# Altium schematic sheet Power Selection - AUX.SchDoc
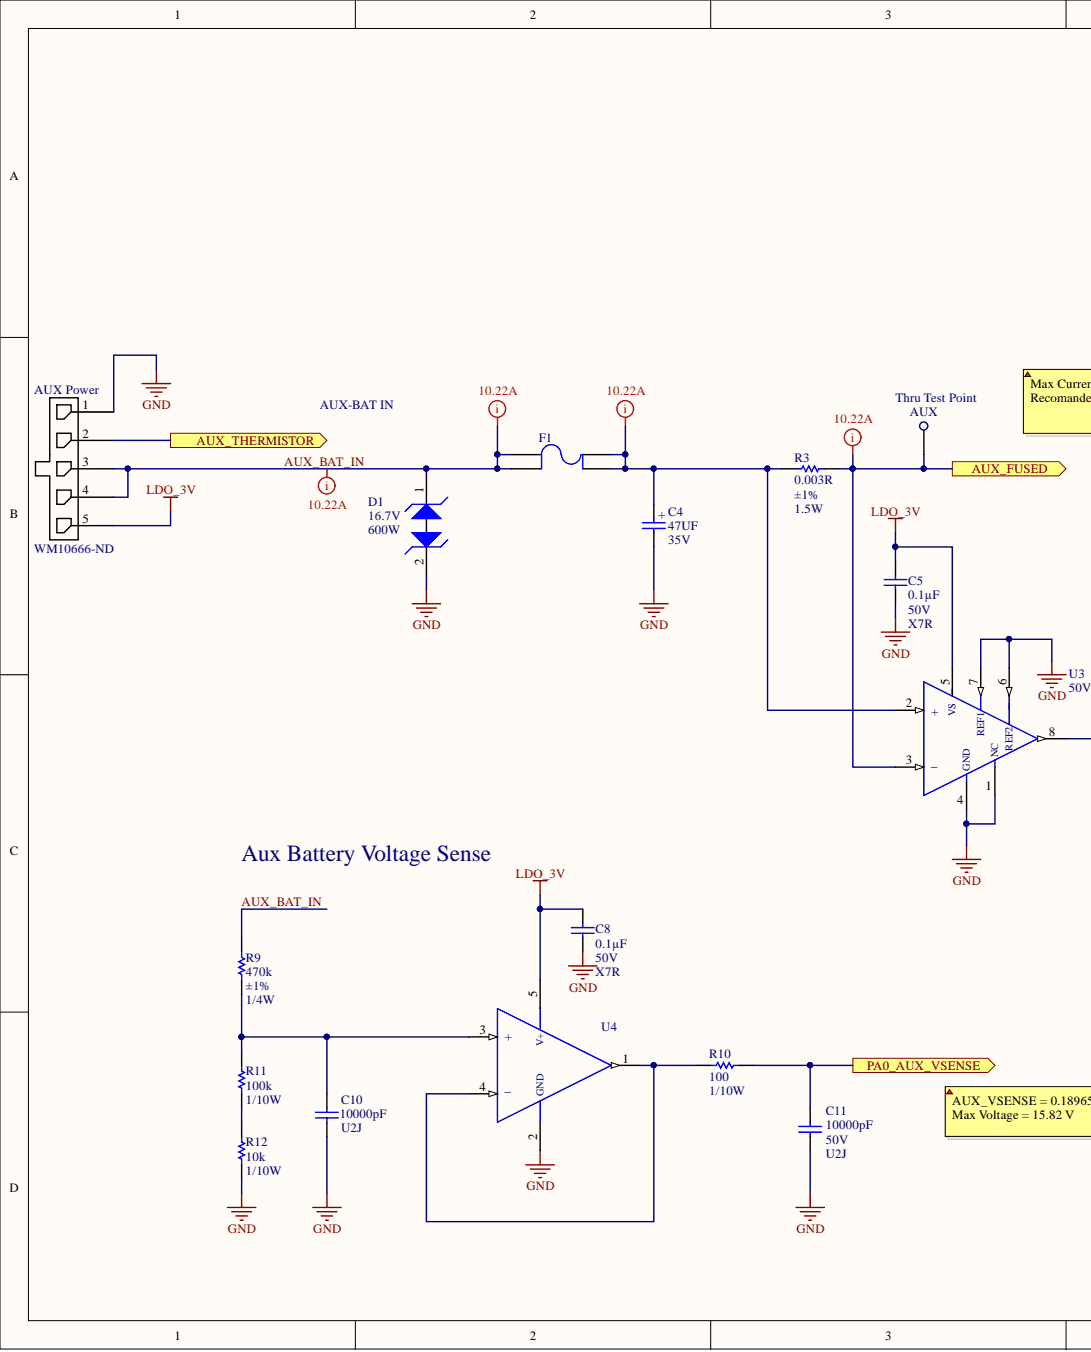
[diagram p0: Power Selection - AUX.SchDoc, left]
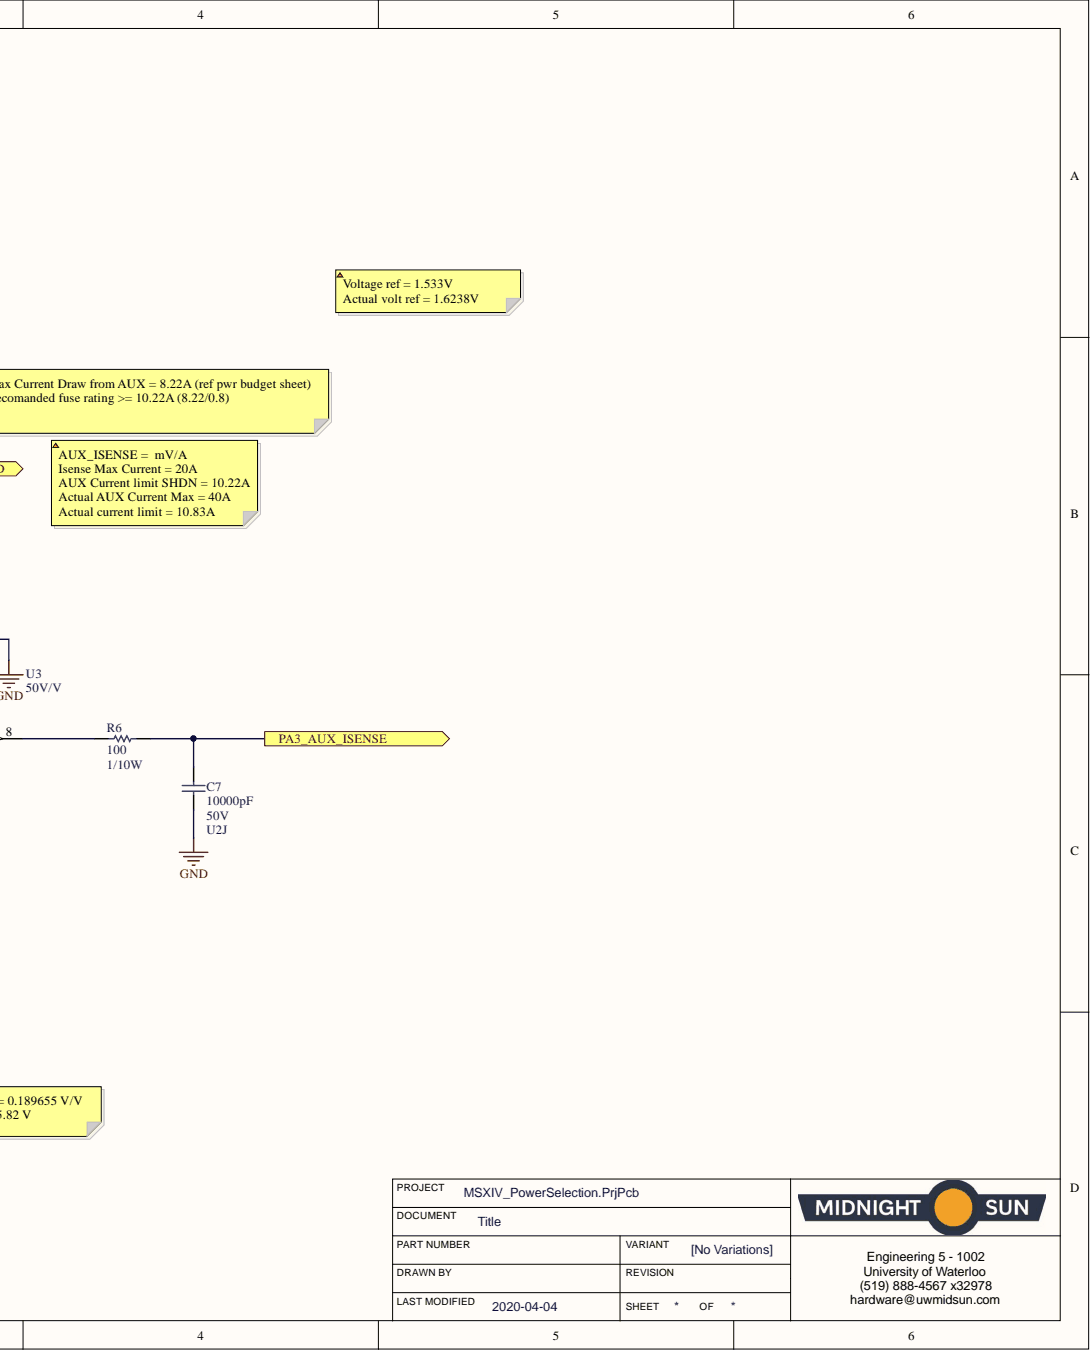
[diagram p1: Power Selection - AUX.SchDoc, right]

## Parts
AUX  Thru Test Point  [Thru Test Point]
AUX_Power  Supplier Part Number 1: WM10666-ND  [CONN, 5 POS MICROFIT SINGAL]  (hidden comment: CONN 5POS MICROFIT SINGAL ROW)
C4  47UF  Voltage Rating: 35V  [CAP ALUM 6.3MM RADIAL SMD]
C5  Capacitance: 0.1µF  Temperature Coefficient: X7R  Voltage: 50V  [CAP, 0603]  (hidden comment: CAP CER 0.1UF 50V 10% X7R 0603)
C7  Capacitance: 10000pF  Temperature Coefficient: U2J  Voltage: 50V  [CAP, 0603]  (hidden comment: CAP CER 10nF 50V 5% X7R 0603)
C8  Capacitance: 0.1µF  Voltage: 50V  [CAP, 0603]  (hidden comment: CAP CER 0.1UF 50V 10% X7R 0603)
C10  Capacitance: 10000pF  Temperature Coefficient: U2J  [CAP, 0603]  (hidden comment: CAP CER 10nF 50V 5% X7R 0603)
C11  Capacitance: 10000pF  Temperature Coefficient: U2J  Voltage: 50V  [CAP, 0603]  (hidden comment: CAP CER 10nF 50V 5% X7R 0603)
D1  Power - Peak Pulse: 600W  Voltage - Breakdown (Min): 16.7V  [DO-214AA Diode]  (hidden comment: DIODE TVS 15VWM 24.4VC DO-214AA (SMB))
F1  (no value)  [FUSE ATO/ATC FUSE HOLDER]
R3  Power (Watts): 1.5W  Resistance (Ohms): 0.003R  Tolerance: ±1%  [RES 2010]  (hidden comment: RES 0.003 OHM 1% 1.5W 2010)
R6  Power: 1/10W  [RES, 0603]  (hidden comment: RES 100 OHM 1% 1/10W 0603)
R9  Power: 1/4W  Resistance: 470k  Tolerance: ±1%  [RES, 0603]  (hidden comment: RES 470K OHM 1% 1/4W 0603)
R10  Power: 1/10W  [RES, 0603]  (hidden comment: RES 100 OHM 1% 1/10W 0603)
R11  Power: 1/10W  Resistance: 100k  [RES, 0603]  (hidden comment: RES 100K OHM 5% 1/8W 0603)
R12  Power: 1/10W  Resistance: 10k  [RES, 0603]  (hidden comment: RES 10K OHM 1% 1/10W 0603)
U3  50V/V  [IC CURRENT AMPLIFIER INA240 TSSOP-8]
U4  IC OP AMP GEN PURPOSE RR 10MHZ SOT-23-5  [IC OP AMP GP RR 10MHZ SOT-23-5]  (value not drawn)

## Ports
AUX_FUSED, AUX_THERMISTOR, PA0_AUX_VSENSE, PA3_AUX_ISENSE

## Nets
GND: AUX_Power.1 C4.2 C5.2 C7.2 C8.2 C10.2 C11.2 D1.2 R12.2 U3.1(NC) U3.4(GND) U3.6 U3.7(REF1) U4.2
LDO_3V: AUX_Power.5 C5.1 C8.1 U3.5(VS) U4.5
AUX_BAT_IN: AUX_Power.3 AUX_Power.4 D1.1 F1.1 F1.3 R9.1
AUX_FUSED: AUX.TH_TP R3.2 U3.3(_) ; port AUX_FUSED
AUX_THERMISTOR: AUX_Power.2 ; port AUX_THERMISTOR
PA0_AUX_VSENSE: C11.1 R10.2 ; port PA0_AUX_VSENSE
PA3_AUX_ISENSE: C7.1 R6.2 ; port PA3_AUX_ISENSE
NetC4_1: C4.1 F1.2 F1.4 R3.1 U3.2(+)
NetC10_1: C10.1 R9.2 R11.1 U4.3(+)
NetR6_1: R6.1 U3.8
NetR10_1: R10.1 U4.1 U4.4
NetR11_2: R11.2 R12.1

## Library data of the parts
C4 = CAP ALUM 47UF 20% 35V SMD: 47µF 35V Aluminum Electrolytic Capacitors Radial, Can - SMD 1000 Hrs @ 85°C; Manufacturer: Panasonic; Manufacturer Part Number: EEE-1VA470WP; Life (Hours): 1000Hour; Ripple Current: 70mA; Tolerance: 20%; Capacitance: 47uF; Case/Package: Radial; Component Kind: Standard; ComponentLink1Description: https://datasheet.ciiva.com/2983/aba0000ce2-2983926.pdf; ComponentLink1URL: https://datasheet.ciiva.com/2983/aba0000ce2-2983926.pdf; ComponentLink2Description: https://datasheet.ciiva.com/2983/aba0000ce2-2983927.pdf; ComponentLink2URL: https://datasheet.ciiva.com/2983/aba0000ce2-2983927.pdf; ComponentLink3Description: https://datasheet.ciiva.com/14598/70255710-14598937.pdf; ComponentLink3URL: https://datasheet.ciiva.com/14598/70255710-14598937.pdf; ComponentLink4Description: https://datasheet.ciiva.com/15165/getdatasheetpartid-86239-15165868.pdf; ComponentLink4URL: https://datasheet.ciiva.com/15165/getdatasheetpartid-86239-15165868.pdf; ComponentLink5Description: https://datasheet.ciiva.com/16507/aba0000ce2-16507965.pdf; ComponentLink5URL: https://datasheet.ciiva.com/16507/aba0000ce2-16507965.pdf; ComponentLink6Description: https://datasheet.ciiva.com/30206/11aba0000ce2-30206253.pdf; ComponentLink6URL: https://datasheet.ciiva.com/30206/11aba0000ce2-30206253.pdf; Contact Plating: Tin; Diameter: 6.3mm; Height: 5.4mm; Height - Seated (Max): 0.213inch; Lead Free: Lead Free; Length: 5.5mm; Max Operating Temperature: 85°C; Min Operating Temperature: -40°C; Mount: Surface Mount,PCB; Package Quantity: 1; Packaging: Tape and Reel; Pricing 1: 1=0.38, 10=0.263, 100=0.1579, 500=0.11846 (USD); Radiation Hardening: No; REACH SVHC: Unknown; Ripple Current (AC): 70mA; Stock 1: 2820; Supplier 1: Digi-Key; Supplier Part Number 1: PCE3961CT-ND; Termination: SMD/SMT; Voltage Rating (DC): 35V; Library Name: Schematic Diagrams.SchLib
C5 C8 = CAP CER 0.1UF 50V 10% X7R 0603: 0.10µF ±10% 50V Ceramic Capacitor X7R 0603 (1608 Metric); Manufacturer 1: AVX Corporation; Manufacturer Part Number 1: 06035C104KAT2A; Component Kind: Standard; ComponentLink1Description: http://datasheets.avx.com/X7RDielectric.pdf; ComponentLink1URL: http://datasheets.avx.com/X7RDielectric.pdf; Height - Seated (Max): -; Lead Spacing: -; Lead Style: -; Mounting Type: Surface Mount, MLCC; Operating Temperature: -55°C ~ 125°C; Package / Case: 0603 (1608 Metric); Packaging: Cut Tape (CT); Part Status: Active; Ratings: -; Size / Dimension: 0.063" L x 0.032" W (1.60mm x 0.81mm); Supplier 1: Digi-Key; Supplier Part Number 1: 478-5052-1-ND; Thickness (Max): 0.035" (0.90mm); Tolerance: ±10%; Library Name: Schematic Diagrams.SchLib
  C8: Temperature Coefficient: X7R
C7 C10 C11 = CAP CER 10nF 50V 5% X7R 0603: 10000pF ±5% 50V Ceramic Capacitor U2J 0603 (1608 Metric); Manufacturer 1: KEMET; Manufacturer Part Number 1: C0603C103J5JAC7867; Component Kind: Standard; ComponentLink1Description: http://www.kemet.com/docfinder?Partnumber=C0603C103J5JAC7867; ComponentLink1URL: http://www.kemet.com/docfinder?Partnumber=C0603C103J5JAC7867; Failure Rate: -; Height - Seated (Max): -; Lead Spacing: -; Lead Style: -; Mounting Type: Surface Mount, MLCC; Operating Temperature: -55°C ~ 125°C; Package / Case: 0603 (1608 Metric); Packaging: Cut Tape (CT); Part Status: Active; Ratings: -; Size / Dimension: 0.063" L x 0.032" W (1.60mm x 0.80mm); Supplier 1: Digi-Key; Supplier Part Number 1: 399-13384-1-ND; Thickness (Max): 0.034" (0.87mm); Tolerance: ±5%; Library Name: Schematic Diagrams.SchLib
  C10: Voltage: 50V
D1 = DIODE TVS 15VWM 24.4VC DO-214AA (SMB): TVS DIODE 15VWM 24.4VC SMB; Manufacturer 1: Fairchild/ON Semiconductor; Manufacturer Part Number 1: SMBJ15CA; Current - Peak Pulse (10/1000µs): 24.6A; Power Line Protection: No; Type: Zener; Voltage - Clamping (Max) @ Ipp: 24.4V; Voltage - Reverse Standoff (Typ): 15V; Applications: General Purpose; Capacitance @ Frequency: -; Category: Circuit Protection; Component Kind: Standard; ComponentLink1Description: https://www.fairchildsemi.com/datasheets/SM/SMBJ10CA.pdf; ComponentLink1URL: https://www.fairchildsemi.com/datasheets/SM/SMBJ10CA.pdf; Description 1: TVS DIODE 15VWM 24.4VC SMB; Mounting Type: Surface Mount; Operating Temperature: -55°C ~ 150°C (TJ); Package / Case: DO-214AA, SMB; Packaging: Cut Tape (CT); Part Status: Active; Pricing 1: 1=0.54, 10=0.444, 100=0.3027, 500=0.22704, 1000=0.17028 (CAD); RoHS: RoHS Compliant; Stock 1: 24965; Supplier 1: Digi-Key; Supplier Device Package: DO-214AA (SMB); Supplier Part Number 1: SMBJ15CAFSCT-ND; Library Name: Schematic Diagrams.SchLib
F1 = FUSE ATO FUSE HOLDER: Fuse Block 30A 500V 1 Circuit Blade PCB; Manufacturer 1: Keystone Electronics; Manufacturer Part Number 1: 3557-2; Description 1: FUSE BLOCK BLADE 500V 30A PCB; For Use With/Related Products: ATC, ATO 257, MINI 897; Fuse Size: 0.43" L x 0.15" W x 0.64" H (10.92mm x 3.81mm x 16.25mm); Number of Circuits: 1; Category: Circuit Protection; Component Kind: Standard; ComponentLink1Description: http://www.keyelco.com/product-pdf.cfm?p=296; ComponentLink1URL: http://www.keyelco.com/product-pdf.cfm?p=296; Contact Finish: Tin Nickel; Contact Material: Brass; Current Rating: 30A; Fuseholder Type: Block; Fuse Type: Blade; Mounting Type: PCB; Orientation: Vertical; Part Status: Active; Pricing 1: 1=1.66, 10=1.442, 50=1.3008, 100=1.2441, 250=1.13096, 500=1.01784, 1000=1.0126, 2500=0.84861, 5000=0.81519 (CAD); RoHS: RoHS Compliant; Stock 1: 48838; Supplier 1: Digi-Key; Supplier Part Number 1: 36-3557-2-ND; Termination Style: PC Pin; Voltage: 500V; Library Name: Schematic Diagrams.SchLib
R3 = RES 0.003 OHM 1% 1.5W 2010: 0.003 Ohm ±1% 1.5W Chip Resistor 2010 (5025 Metric) Current Sense, Moisture Resistant Metal Foil; Manufacturer 1: Stackpole Electronics Inc.; Manufacturer Part Number 1: CSNL2010FT3L00; Description 1: RES SMD 0.003 OHM 1% 1.5W 2010; Temperature Coefficient: ±50ppm/°C; Category: Resistors; Component Kind: Standard; ComponentLink1Description: https://www.seielect.com/Catalog/SEI-csnl.pdf; ComponentLink1URL: https://www.seielect.com/Catalog/SEI-csnl.pdf; Composition: Metal Foil; Failure Rate: -; Features: Current Sense, Moisture Resistant; Height - Seated (Max): 0.041" (1.04mm); Operating Temperature: -55°C ~ 170°C; Package / Case: 2010 (5025 Metric); Packaging: Cut Tape (CT); Part Status: Active; Pricing 1: 1=1.02, 10=0.844, 25=0.7232, 50=0.6508, 100=0.5665, 250=0.5062, 500=0.4098, 1000=0.33145 (CAD); RoHS: RoHS Compliant; Size / Dimension: 0.200" L x 0.100" W (5.08mm x 2.54mm); Stock 1: 18532; Supplier 1: Digi-Key; Supplier Device Package: 2010; Supplier Part Number 1: CSNL2010FT3L00CT-ND; Library Name: Schematic Diagrams.SchLib
R6 R10 = RES 100 OHM 1% 1/10W 0603: 100 Ohm ±1% 0.1W, 1/10W Chip Resistor 0603 (1608 Metric) Moisture Resistant Thick Film; Manufacturer 1: Yageo; Manufacturer Part Number 1: RC0603FR-07100RL; Resistance: 100; Temperature Coefficient: ±100ppm/°C; Component Kind: Standard; ComponentLink1Description: http://www.yageo.com/documents/recent/PYu-RC_Group_51_RoHS_L_7.pdf; ComponentLink1URL: http://www.yageo.com/documents/recent/PYu-RC_Group_51_RoHS_L_7.pdf; Composition: Thick Film; Failure Rate: -; Height - Seated (Max): 0.022" (0.55mm); Operating Temperature: -55°C ~ 155°C; Package / Case: 0603 (1608 Metric); Packaging: Cut Tape (CT); Part Status: Active; Size / Dimension: 0.063" L x 0.031" W (1.60mm x 0.80mm); Supplier 1: Digi-Key; Supplier Device Package: 0603; Supplier Part Number 1: 311-100HRCT-ND; Tolerance: ±1%; Library Name: Schematic Diagrams.SchLib
R9 = RES 470K OHM 1% 1/4W 0603: RES SMD 470K OHM 1% 1/4W 0603; Manufacturer 1: Panasonic Electronic Components; Manufacturer Part Number 1: ERJ-PA3F4703V; Temperature Coefficient: ±100ppm/°C; Component Kind: Standard; ComponentLink1Description: https://industrial.panasonic.com/cdbs/www-data/pdf/RDO0000/AOA0000C291.pdf; ComponentLink1URL: https://industrial.panasonic.com/cdbs/www-data/pdf/RDO0000/AOA0000C291.pdf; Composition: Thick Film; Failure Rate: -; Height - Seated (Max): 0.022" (0.55mm); Operating Temperature: -55°C ~ 155°C; Package / Case: 0603 (1608 Metric); Packaging: Cut Tape (CT); Part Status: Active; Size / Dimension: 0.063" L x 0.031" W (1.60mm x 0.80mm); Supplier 1: Digi-Key; Supplier Device Package: 0603; Supplier Part Number 1: P470KBYCT-ND; Library Name: Schematic Diagrams.SchLib
R11 = RES 100K OHM 5% 1/8W 0603: RES SMD 100K OHM 5% 1/10W 0603; Manufacturer 1: Yageo; Manufacturer Part Number 1: RC0603JR-07100KL; Temperature Coefficient: ±100ppm/°C; Component Kind: Standard; ComponentLink1Description: http://www.yageo.com/documents/recent/PYu-RC_Group_51_RoHS_L_7.pdf; ComponentLink1URL: http://www.yageo.com/documents/recent/PYu-RC_Group_51_RoHS_L_7.pdf; Composition: Thick Film; Failure Rate: -; Height - Seated (Max): 0.022" (0.55mm); Operating Temperature: -55°C ~ 155°C; Package / Case: 0603 (1608 Metric); Packaging: Cut Tape (CT); Part Status: Active; Size / Dimension: 0.063" L x 0.031" W (1.60mm x 0.80mm); Supplier 1: Digi-Key; Supplier Device Package: 0603; Supplier Part Number 1: 311-100KGRCT-ND; Tolerance: ±5%; Library Name: Schematic Diagrams.SchLib
R12 = RES 10K OHM 1% 1/10W 0603: RES SMD 10K OHM 1% 1/10W 0603; Manufacturer 1: Yageo; Manufacturer Part Number 1: RC0603FR-0710KL; Temperature Coefficient: ±100ppm/°C; Component Kind: Standard; ComponentLink1Description: http://www.yageo.com/documents/recent/PYu-RC_Group_51_RoHS_L_7.pdf; ComponentLink1URL: http://www.yageo.com/documents/recent/PYu-RC_Group_51_RoHS_L_7.pdf; Composition: Thick Film; Failure Rate: -; Height - Seated (Max): 0.022" (0.55mm); Operating Temperature: -55°C ~ 155°C; Package / Case: 0603 (1608 Metric); Packaging: Cut Tape (CT); Part Status: Active; Size / Dimension: 0.063" L x 0.031" W (1.60mm x 0.80mm); Supplier 1: Digi-Key; Supplier Device Package: 0603; Supplier Part Number 1: 311-10.0KHRCT-ND; Tolerance: ±1%; Library Name: Schematic Diagrams.SchLib
U3 = IC CURRENT AMPLIFIER INA240 8-TSSOP: Current Sense Amplifier 1 Circuit 8-TSSOP; Manufacturer 1: Texas Instruments; Manufacturer Part Number 1: INA240A3PWR; Current - Supply: 1.8mA; Description 1: IC AMP CURRENT SENSE 8-TSSOP; Slew Rate: 2 V/µs; Voltage - Supply, Single/Dual (±): 2.7 V ~ 5.5 V; Amplifier Type: Current Sense; Category: Integrated Circuits (ICs); Component Kind: Standard; ComponentLink1Description: http://www.ti.com/lit/ds/symlink/ina240.pdf; ComponentLink1URL: http://www.ti.com/lit/ds/symlink/ina240.pdf; Mounting Type: Surface Mount; Operating Temperature: -40°C ~ 125°C; Output Type: -; Package / Case: 8-TSSOP (0.173", 4.40mm Width); Packaging: Cut Tape (CT); Part Status: Active; Pricing 1: 1=3.79, 10=3.397, 100=2.7311, 500=2.24388, 1000=1.85921 (CAD); RoHS: RoHS Compliant; Stock 1: 1286; Supplier 1: Digi-Key; Supplier Device Package: 8-TSSOP; Supplier Part Number 1: 296-45090-1-ND; Library Name: Schematic Diagrams.SchLib
U4 = IC OP AMP GEN PURPOSE RR 10MHZ SOT-23-5: General Purpose Amplifier 1 Circuit Rail-to-Rail SOT-23-5; Manufacturer 1: Texas Instruments; Manufacturer Part Number 1: TLV316QDBVRQ1; Current - Output / Channel: 50mA; Current - Supply: 400µA; Description 1: IC OP AMP GP RR 10MHZ 5-SOT-23; Number of Circuits: 1; Slew Rate: 6 V/µs; Voltage - Supply, Single/Dual (±): 1.8 V ~ 5.5 V, ±0.9 V ~ 2.75 V; -3db Bandwidth: -; Amplifier Type: General Purpose; Category: Integrated Circuits (ICs); Component Kind: Standard; ComponentLink1Description: http://www.ti.com/lit/ds/symlink/tlv316-q1.pdf; ComponentLink1URL: http://www.ti.com/lit/ds/symlink/tlv316-q1.pdf; Mounting Type: Surface Mount; Operating Temperature: -40°C ~ 125°C; Output Type: Rail-to-Rail; Package / Case: SC-74A, SOT-753; Packaging: Cut Tape (CT); Part Status: Active; Pricing 1: 1=1.33, 10=1.185, 100=0.9234, 500=0.76276, 1000=0.60218 (CAD); RoHS: RoHS Compliant; Stock 1: 1747; Supplier 1: Digi-Key; Supplier Device Package: SOT-23-5; Supplier Part Number 1: 296-45323-1-ND; Library Name: Schematic Diagrams.SchLib
AUX = Thru Test Point: Component Kind: Standard; Library Name: Schematic Diagrams.SchLib
AUX_Power = CONN 5POS MICROFIT SINGAL ROW: Component Kind: Standard; ComponentLink1Description: https://www.molex.com/pdm_docs/sd/436500327_sd.pdf; ComponentLink1URL: https://www.molex.com/pdm_docs/sd/436500327_sd.pdf; ComponentLink2Description: https://www.molex.com/pdm_docs/ps/PS-43650.pdf; ComponentLink2URL: https://www.molex.com/pdm_docs/ps/PS-43650.pdf; Supplier 1: Digi-Key; Library Name: Schematic Diagrams.SchLib
